# Altium schematic sheet ICWSHLP2_Head_2x2_ASIC1.SchDoc(ICWSHLP2_Head_2x2_ASIC1)
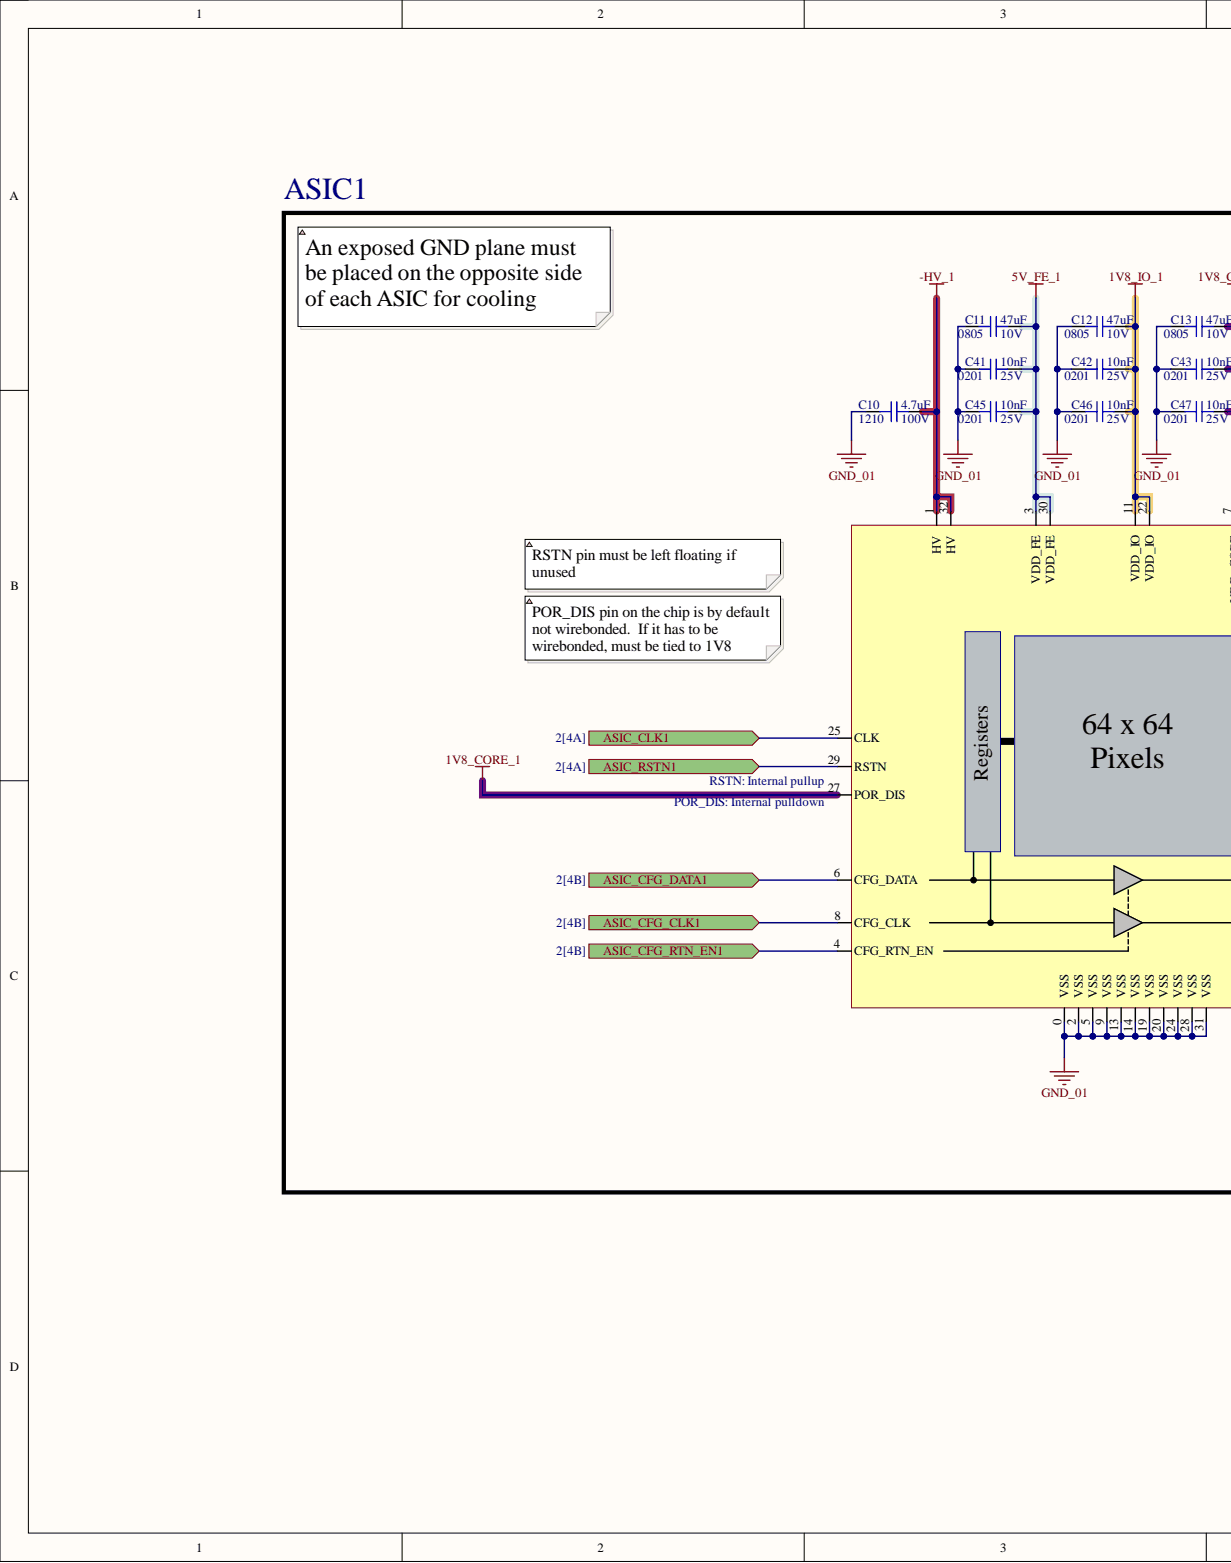
[diagram p0: ICWSHLP2_Head_2x2_ASIC1.SchDoc, left]
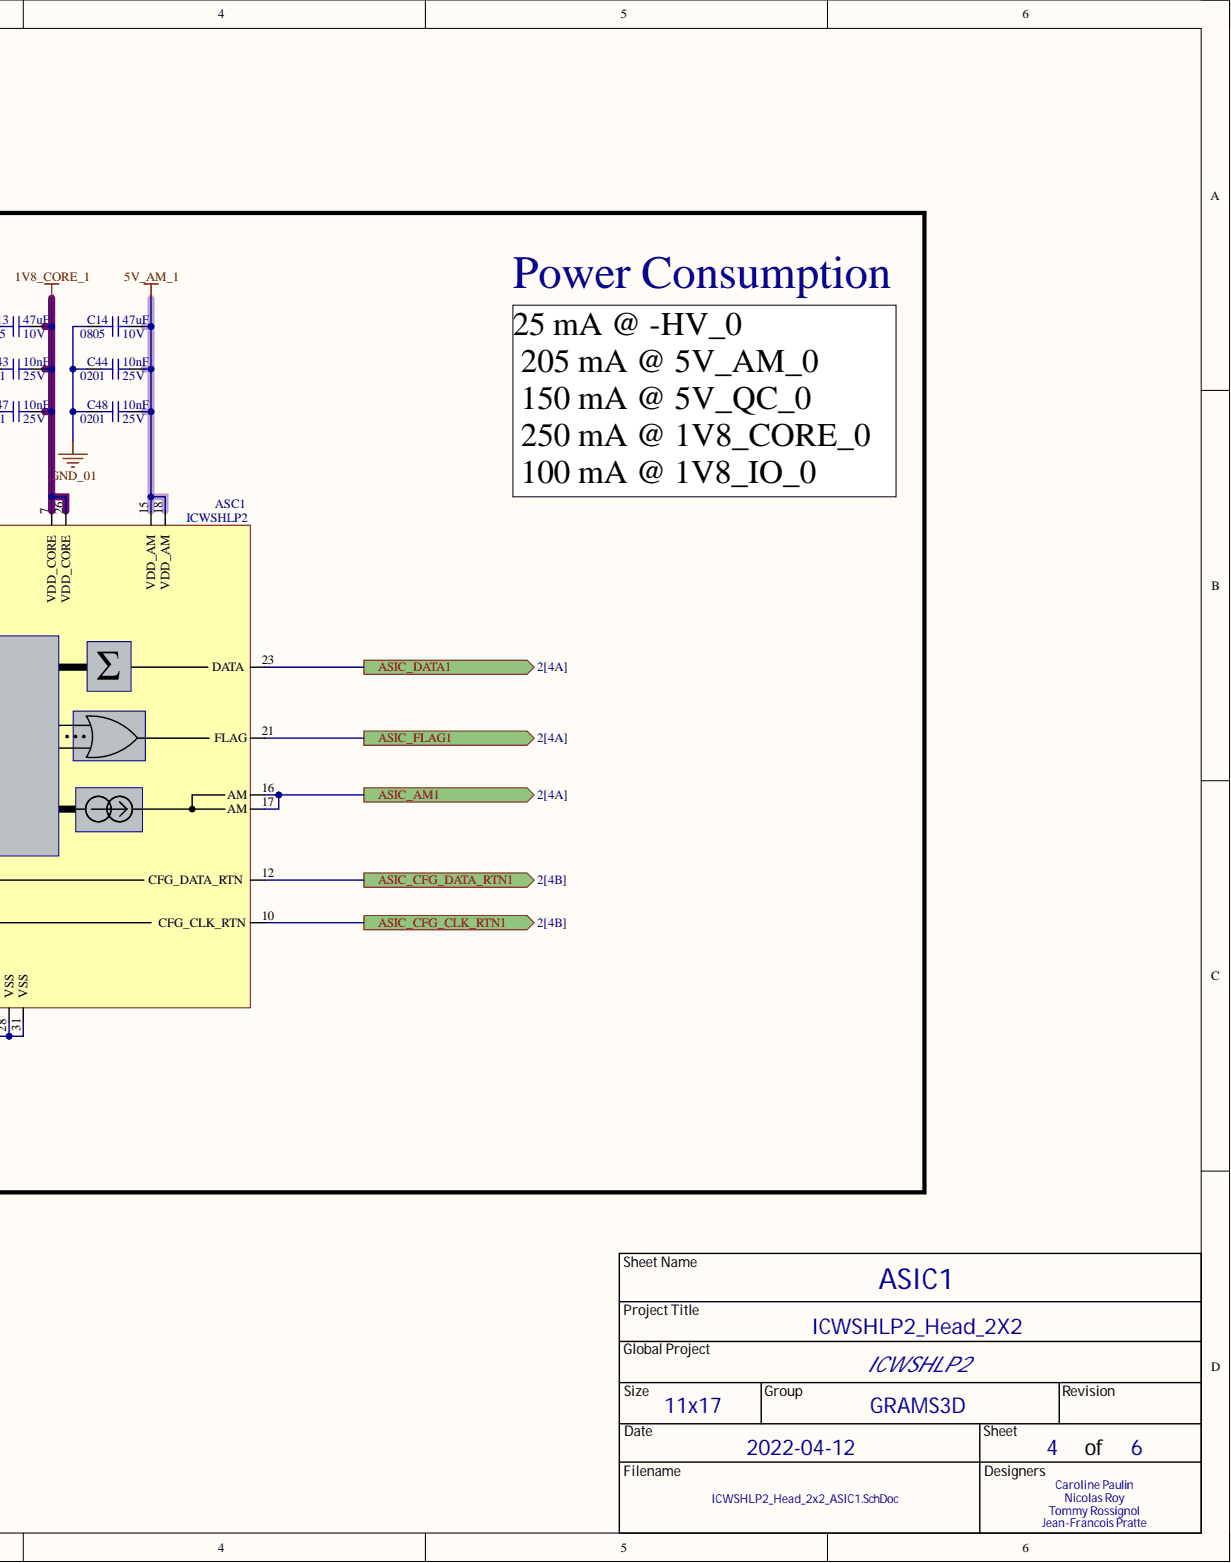
[diagram p1: ICWSHLP2_Head_2x2_ASIC1.SchDoc, right]

## Parts
ASC1  ICWSHLP2  [ASC_ICWSHLP2]
C10  value 4.7uF  Package: 1210  Voltage Rating: 100V  [CAP_CER_1210]  (hidden comment: GCM32DC72A475KE02L)
C11  value 47uF  Package: 0805  Voltage Rating: 10V  [CAP_CER_0805]  (hidden comment: GRM21BR61A476ME15L)
C12  value 47uF  Package: 0805  Voltage Rating: 10V  [CAP_CER_0805]  (hidden comment: GRM21BR61A476ME15L)
C13  value 47uF  Package: 0805  Voltage Rating: 10V  [CAP_CER_0805]  (hidden comment: GRM21BR61A476ME15L)
C14  value 47uF  Package: 0805  Voltage Rating: 10V  [CAP_CER_0805]  (hidden comment: GRM21BR61A476ME15L)
C41  value 10nF  Package: 0201  Voltage Rating: 25V  [CAP_CER_0201]  (hidden comment: TMK063B7103KP-F)
C42  value 10nF  Package: 0201  Voltage Rating: 25V  [CAP_CER_0201]  (hidden comment: TMK063B7103KP-F)
C43  value 10nF  Package: 0201  Voltage Rating: 25V  [CAP_CER_0201]  (hidden comment: TMK063B7103KP-F)
C44  value 10nF  Package: 0201  Voltage Rating: 25V  [CAP_CER_0201]  (hidden comment: TMK063B7103KP-F)
C45  value 10nF  Package: 0201  Voltage Rating: 25V  [CAP_CER_0201]  (hidden comment: TMK063B7103KP-F)
C46  value 10nF  Package: 0201  Voltage Rating: 25V  [CAP_CER_0201]  (hidden comment: TMK063B7103KP-F)
C47  value 10nF  Package: 0201  Voltage Rating: 25V  [CAP_CER_0201]  (hidden comment: TMK063B7103KP-F)
C48  value 10nF  Package: 0201  Voltage Rating: 25V  [CAP_CER_0201]  (hidden comment: TMK063B7103KP-F)

## Ports
ASIC_AM1, ASIC_CFG_CLK1, ASIC_CFG_CLK_RTN1, ASIC_CFG_DATA1, ASIC_CFG_DATA_RTN1, ASIC_CFG_RTN_EN1, ASIC_CLK1, ASIC_DATA1, ASIC_FLAG1, ASIC_RSTN1

## Nets
1V8_CORE_1: ASC1.7(VDD_CORE) ASC1.26(VDD_CORE) ASC1.27(POR_DIS) C13.2 C43.1 C47.1
1V8_IO_1: ASC1.11(VDD_IO) ASC1.22(VDD_IO) C12.2 C42.1 C46.1
5V_AM_1: ASC1.15(VDD_AM) ASC1.18(VDD_AM) C14.2 C44.1 C48.1
5V_FE_1: ASC1.3(VDD_FE) ASC1.30(VDD_FE) C11.2 C41.1 C45.1
-HV_1: ASC1.1(HV) ASC1.32(HV) C10.1
GND_01: ASC1.0(VSS) ASC1.2(VSS) ASC1.5(VSS) ASC1.9(VSS) ASC1.13(VSS) ASC1.14(VSS) ASC1.19(VSS) ASC1.20(VSS) ASC1.24(VSS) ASC1.28(VSS) ASC1.31(VSS) C10.2 C11.1 C12.1 C13.1 C14.1 C41.2 C42.2 C43.2 C44.2 C45.2 C46.2 C47.2 C48.2
ASIC_AM1: ASC1.16(AM) ASC1.17(AM) ; port ASIC_AM1
ASIC_CFG_CLK1: ASC1.8(CFG_CLK) ; port ASIC_CFG_CLK1
ASIC_CFG_CLK_RTN1: ASC1.10(CFG_CLK_RTN) ; port ASIC_CFG_CLK_RTN1
ASIC_CFG_DATA1: ASC1.6(CFG_DATA) ; port ASIC_CFG_DATA1
ASIC_CFG_DATA_RTN1: ASC1.12(CFG_DATA_RTN) ; port ASIC_CFG_DATA_RTN1
ASIC_CFG_RTN_EN1: ASC1.4(CFG_RTN_EN) ; port ASIC_CFG_RTN_EN1
ASIC_CLK1: ASC1.25(CLK) ; port ASIC_CLK1
ASIC_DATA1: ASC1.23(DATA) ; port ASIC_DATA1
ASIC_FLAG1: ASC1.21(FLAG) ; port ASIC_FLAG1
ASIC_RSTN1: ASC1.29(RSTN) ; port ASIC_RSTN1

## Library data of the parts
ASC1 = ASC_ICWSHLP2: Rev 2. CMOS SPAD Readout for 3D PDC in Low Power Applications.; Manufacturer #1: TSMC 180 nm BCD; GRAMS Lib Spec: 2.7; Magnetic Material: No; Polarized: Yes; Voltage Rating: 1.8V/5.0V; Assembly Type: Wirebonding; Component Kind: Standard; Library Name: GRAMS_MASTER_LIB_SCH.SchLib; Package: Bare Die; Part Number #1: ICWSHLP2; Supplier 1: TSMC; Supplier Part Number 1: ICWSHLP2; Temperature: -200 to 125 degC; CreatedBy/Date: Nicolas Roy/24 sept. 2018; VerifiedBy: Nicolas Roy
C10 = CAP_CER_GCM32DC72A475KE02L: CAP CER 4.7UF 100V X7S 1210; Manufacturer #1: Murata Electronics; Cryo: UNKNOWN; GRAMS Lib Spec: 2.8; Polarized: NO; Tolerance: 5%; Assembly Type: SMD; Component Kind: Standard; HelpURL: https://search.murata.co.jp/Ceramy/image/img/A01X/G101/ENG/GCM32DC72A475KE02-01.pdf; Magnetic Material: UNKNOWN; Part Number #1: GCM32DC72A475KE02L; Solder Paste Type: UNKNOWN; Stencil Thickness (Recommended): UNKNOWN; Supplier 1: Digi-Key; Supplier Part Number 1: 490-14484-1-ND; Temperature: -55°C ~ 125°C; CreatedBy/Date: Thomas G-C 2021-07-01; Library Name: Lib_temp_Thomas_GC.SchLib
C11-C14 = CAP_CER_GRM21BR61A476ME15L: CAP - CER 47uF 20% 10V X5R - 0805; Manufacturer #1: Murata; Cryo: UNKNOWN; GRAMS Lib Spec: 2.8; Polarized: No; Assembly Type: SMD; Component Kind: Standard; HelpURL: http://search.murata.co.jp/Ceramy/image/img/A01X/G101/ENG/GRM21BR61A476ME15-01.pdf; Library Name: GRAMS_MASTER_LIB_SCH.SchLib; Magnetic Material: UNKNOWN; Part Number #1: GRM21BR61A476ME15L; Solder Paste Type: UNKNOWN; Stencil Thickness (Recommended): UNKNOWN; Supplier 1: Digi-Key; Supplier Part Number 1: 490-9961-1-ND; Temperature: -55°C ~ 85°C; Tolerance: 20%; CreatedBy/Date: Joel Lemay 2018-06-19; VerifiedBy: Caroline Paulin - 7 sept 2018
C41-C48 = CAP_CER_TMK063B7103KP-F: CAP - CER 10nF 10% 25V X7R - 0201; Manufacturer #1: Taiyo Yuden; Cryo: UNKNOWN; GRAMS Lib Spec: 2.8; Polarized: No; Solder Paste Type: TYPE 3; Stencil Thickness (Recommended): MAX 5 MILS; Assembly Type: SMD; Component Kind: Standard; HelpURL: http://ds.yuden.co.jp/TYCOMPAS/ut/detail.do?productNo=TMK063B7103KP-F&fileName=TMK063B7103KP-F_SS&mode=specSheetDownload; Library Name: GRAMS_MASTER_LIB_SCH.SchLib; Magnetic Material: UNKNOWN; Part Number #1: TMK063B7103KP-F; Supplier 1: Digi-Key; Supplier Part Number 1: 587-5943-1-ND; Temperature: -55°C ~ 125°C; Tolerance: 10%; CreatedBy/Date: Nicolas Roy - 13 dec. 2018; VerifiedBy: Nicolas V. (2019-01-22)
